# Altium schematic sheet BOLT_MCU_Power.SchDoc(BOLT_MCU_Power)
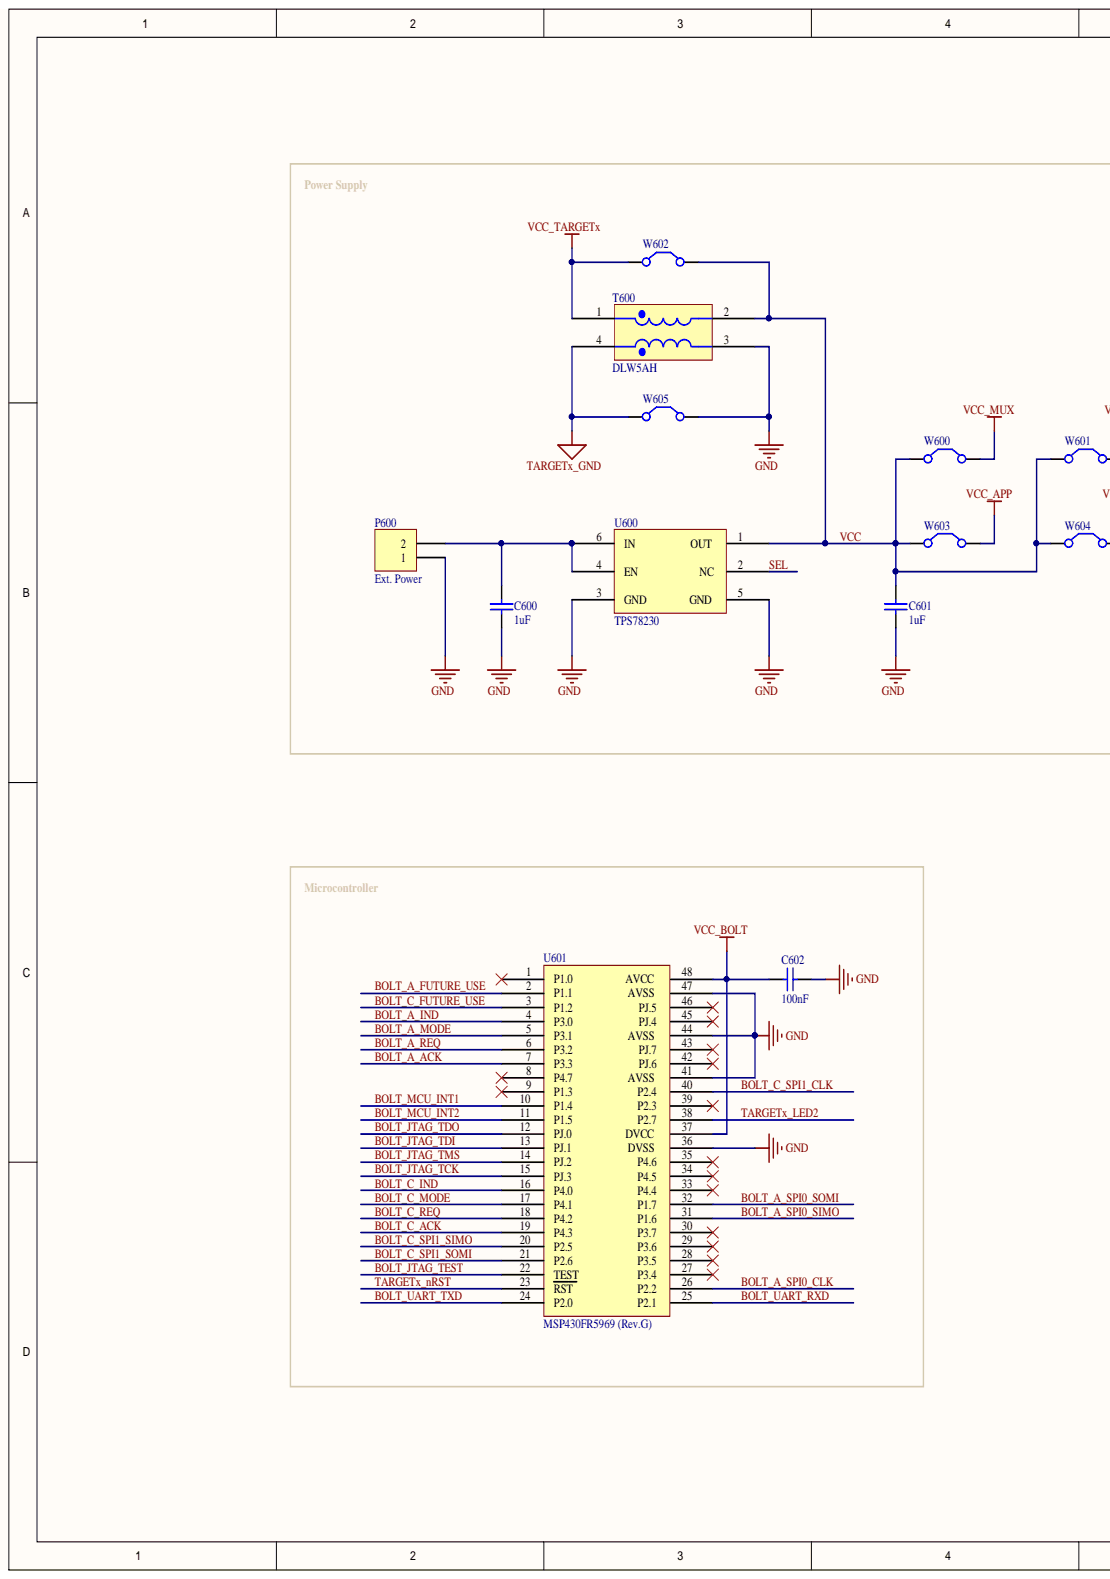
[diagram p0: BOLT_MCU_Power.SchDoc, left]
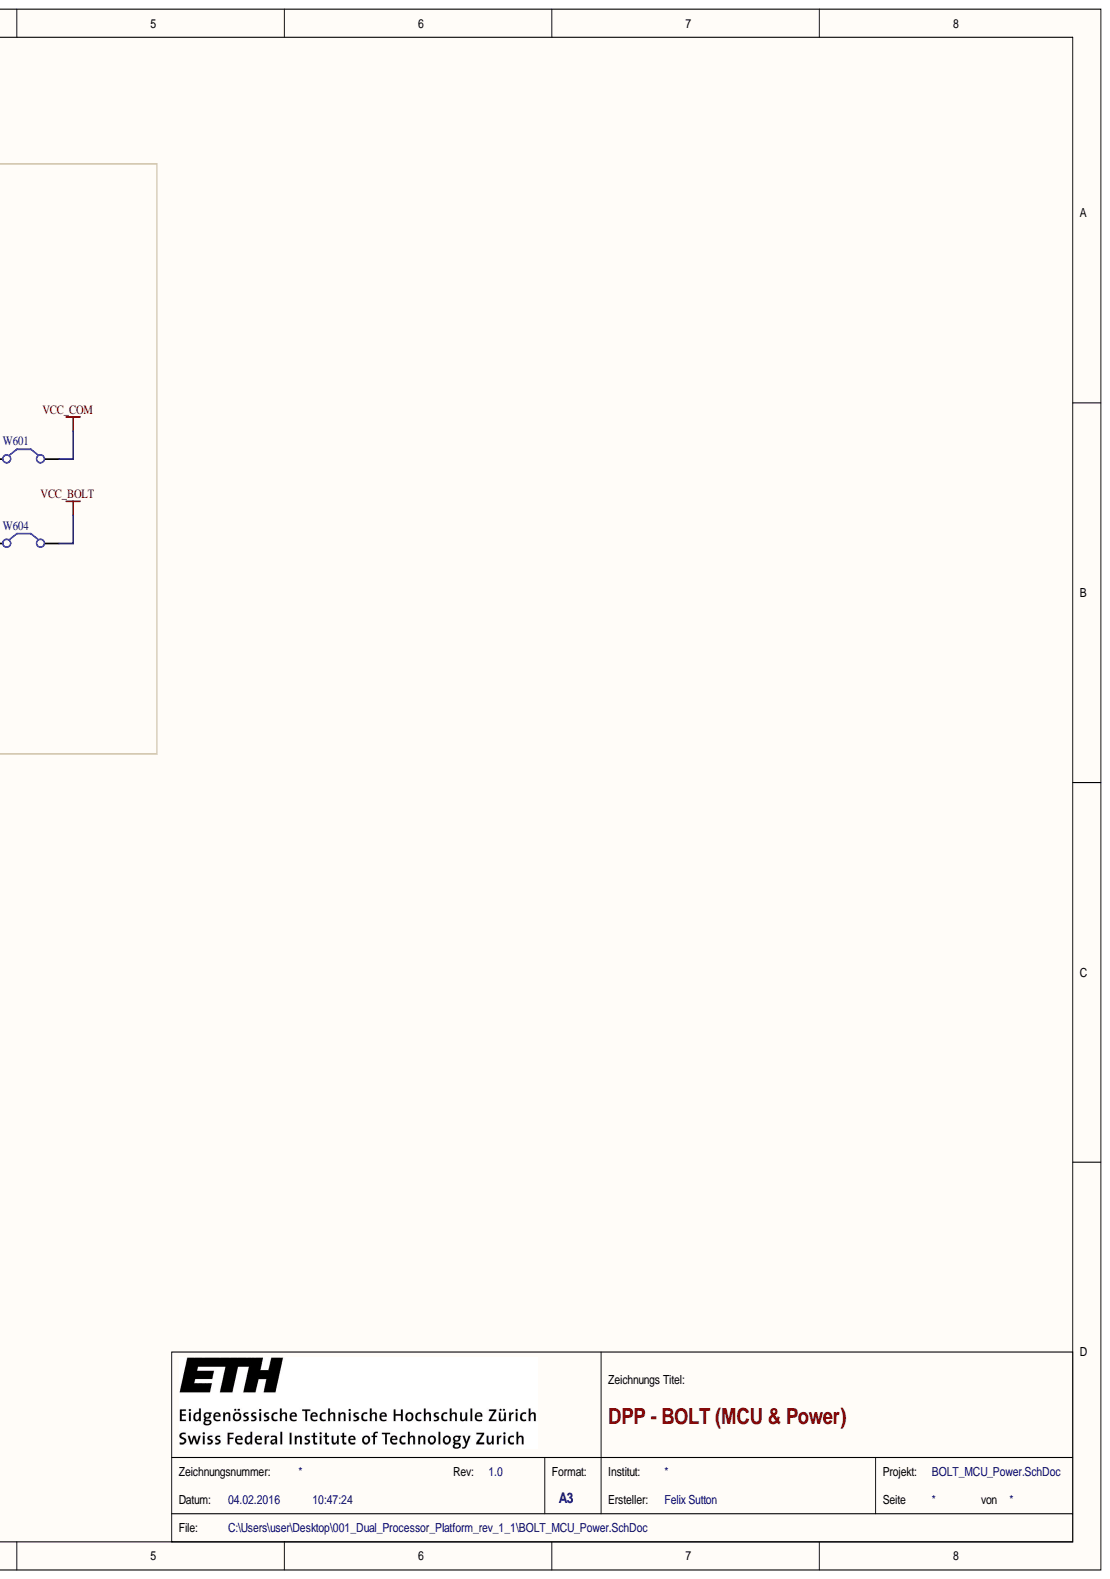
[diagram p1: BOLT_MCU_Power.SchDoc, right]

## Parts
C600  1uF  [CAPC1608N]
C601  1uF  [CAPC1608N]
C602  100nF  [CAPC1608N]
P600  Function: Ext. Power  [HDR1X2]  (hidden comment: Header 2)
T600  DLW5AH  [DLW5AH]
U600  TPS78230  [SON6]
U601  MSP430FR5969 (Rev.G)  [RGZ_S_PVQFN_N48]
W600  (no value)  [1X2_127_HDR]
W601  (no value)  [1X2_127_HDR]
W602  (no value)  [RESC1608M]
W603  (no value)  [1X2_127_HDR]
W604  (no value)  [1X2_127_HDR]
W605  (no value)  [RESC1608M]

## Nets
GND: C600.1 C601.1 C602.1 P600.1 T600.3 U600.3(GND) U600.5(GND) U601.36(DVSS) U601.41(AVSS) U601.44(AVSS) U601.47(AVSS) W605.2
TARGETX_GND: T600.4 W605.1
VCC_APP: W603.2
VCC_BOLT: C602.2 U601.37(DVCC) U601.48(AVCC) W604.2
VCC_COM: W601.2
VCC_MUX: W600.2
VCC_TARGETX: T600.1 W602.1
BOLT_A_ACK: U601.7(P3.3)
BOLT_A_FUTURE_USE: U601.2(P1.1)
BOLT_A_IND: U601.4(P3.0)
BOLT_A_MODE: U601.5(P3.1)
BOLT_A_REQ: U601.6(P3.2)
BOLT_A_SPI0_CLK: U601.26(P2.2)
BOLT_A_SPI0_SIMO: U601.31(P1.6)
BOLT_A_SPI0_SOMI: U601.32(P1.7)
BOLT_C_ACK: U601.19(P4.3)
BOLT_C_FUTURE_USE: U601.3(P1.2)
BOLT_C_IND: U601.16(P4.0)
BOLT_C_MODE: U601.17(P4.1)
BOLT_C_REQ: U601.18(P4.2)
BOLT_C_SPI1_CLK: U601.40(P2.4)
BOLT_C_SPI1_SIMO: U601.20(P2.5)
BOLT_C_SPI1_SOMI: U601.21(P2.6)
BOLT_JTAG_TCK: U601.15(PJ.3)
BOLT_JTAG_TDI: U601.13(PJ.1)
BOLT_JTAG_TDO: U601.12(PJ.0)
BOLT_JTAG_TEST: U601.22(TEST)
BOLT_JTAG_TMS: U601.14(PJ.2)
BOLT_MCU_INT1: U601.10(P1.4)
BOLT_MCU_INT2: U601.11(P1.5)
BOLT_UART_RXD: U601.25(P2.1)
BOLT_UART_TXD: U601.24(P2.0)
SEL: U600.2(NC)
TARGETX_LED2: U601.38(P2.7)
TARGETX_NRST: U601.23(RST)
VCC: C601.2 T600.2 U600.1(OUT) W600.1 W601.1 W602.2 W603.1 W604.1
NetC600_2: C600.2 P600.2 U600.4(EN) U600.6(IN)

Not connected: U601 1(P1.0) 8(P4.7) 9(P1.3) 27(P3.4) 28(P3.5) 29(P3.6) 30(P3.7) 33(P4.4) 34(P4.5) 35(P4.6) 39(P2.3) 42(PJ.6) 43(PJ.7) 45(PJ.4) 46(PJ.5)

## Library data of the parts
C600-C602 = Cap: Capacitor; Component Kind: Standard; LatestRevisionDate: 17-Jul-2002; LatestRevisionNote: Re-released for DXP Platform.; Library Name: Miscellaneous Devices.IntLib; PackageReference: RAD-0.3; Published: 8-Jun-2000; Publisher: Altium Limited
P600 = Header 2: Header, 2-Pin; Component Kind: Standard; Library Name: PermaSense.SchLib
T600 = DLW5AH: Murata DLW5AH Common Mode Choke; Component Kind: Standard; Library Name: Felix_Component_Library.SchLib
U600 = TPS78230: TI TPS78230 3.0V LDO; Component Kind: Standard; Library Name: Felix_Component_Library.SchLib
U601 = MSP430FR5969: TI MSP430FR5969 MCU+FRAM; Component Kind: Standard; Library Name: Felix_Component_Library.SchLib
W600-W605 = Jumper: Jumper Wire; Component Kind: Net Tie; LatestRevisionDate: 17-Jul-2002; LatestRevisionNote: Re-released for DXP Platform.; Library Name: Miscellaneous Devices.IntLib; PackageReference: RAD-0.2; Published: 24-Mar-1999; Publisher: Altium Limited
